# Altium schematic sheet uController_LCD.SchDoc
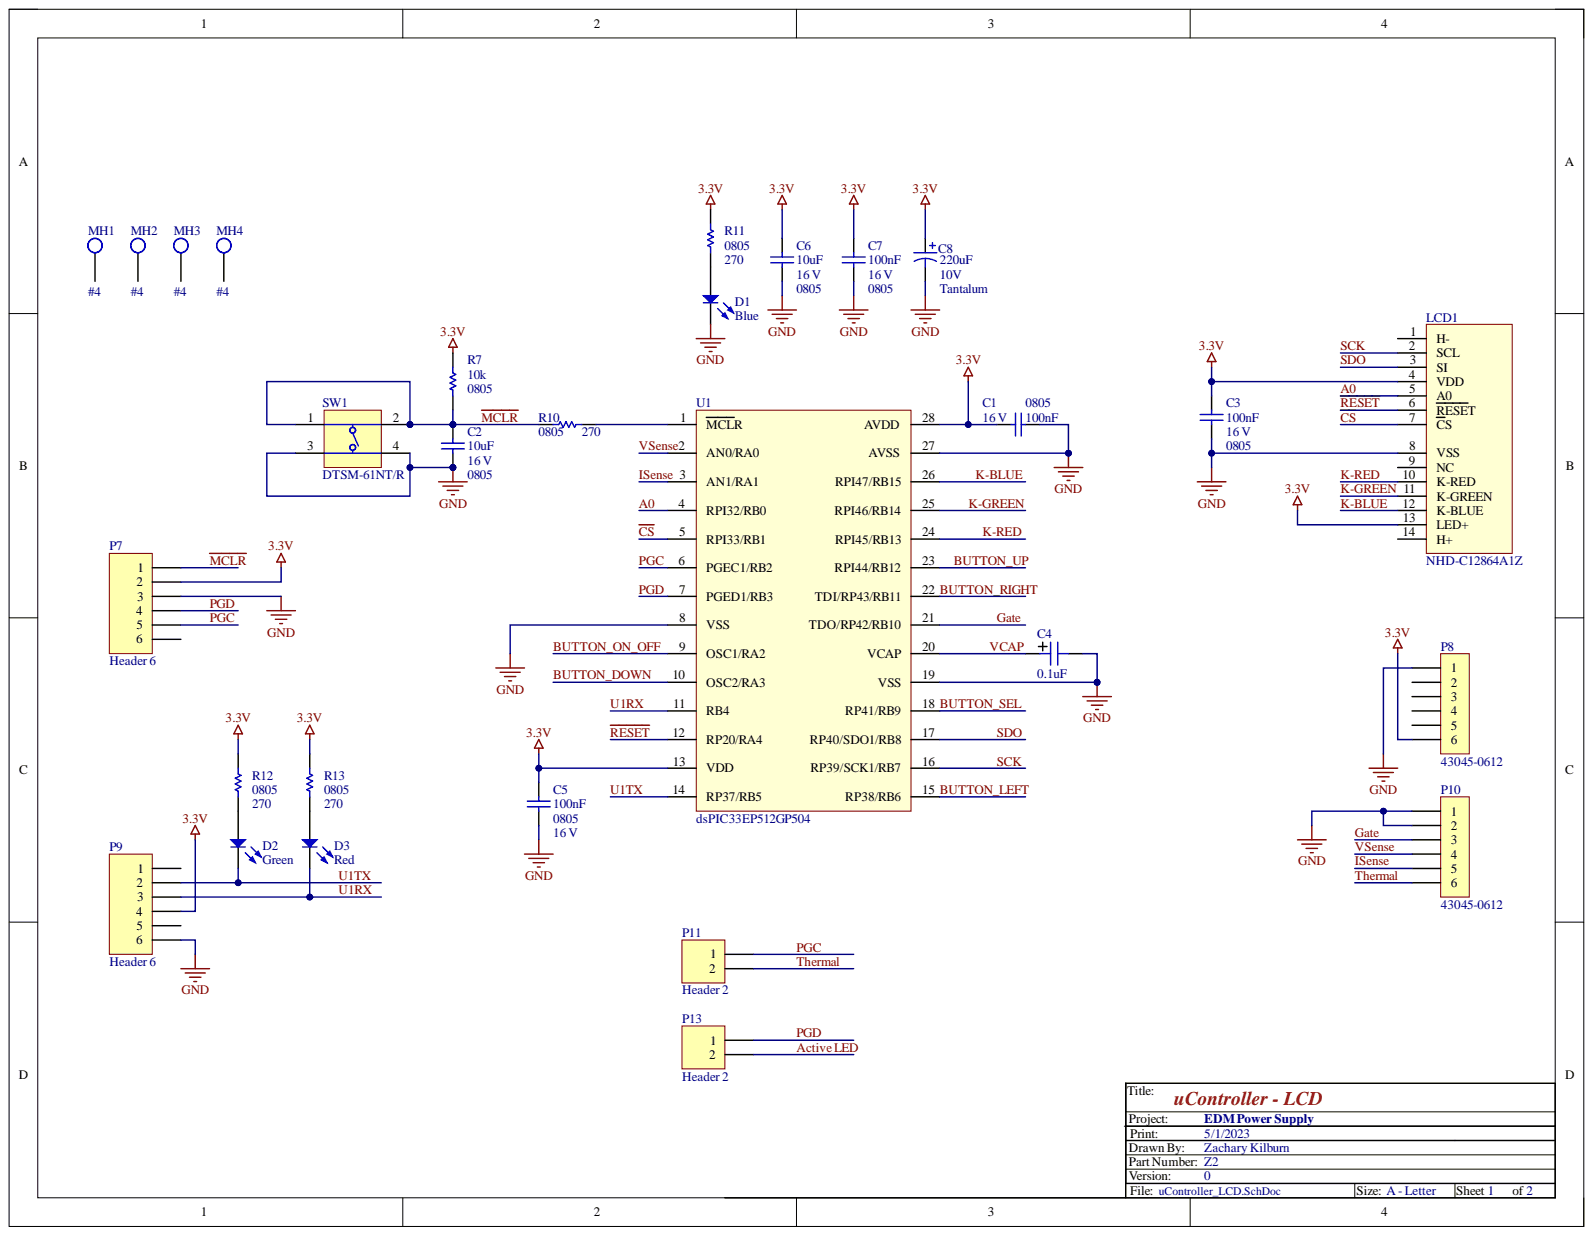
[diagram p0: uController_LCD.SchDoc]

## Parts
C1  value 100nF  Case-EIA: 0805  Rated Voltage: 16 V  [CAPC0805(2012)145_M]  (hidden comment: CAP 100nF 16V 0805(2012))
C2  value 10uF  Case-EIA: 0805  Rated Voltage: 16 V  [CAPC0805(2012)100_L]  (hidden comment: CAP 10uF 16V 0805(2012))
C3  value 100nF  Case-EIA: 0805  Rated Voltage: 16 V  [CAPC0805(2012)145_M]  (hidden comment: CAP 100nF 16V 0805(2012))
C4  0.1uF  [CAP POL 2917/7343]
C5  value 100nF  Case-EIA: 0805  Rated Voltage: 16 V  [CAPC0805(2012)145_M]  (hidden comment: CAP 100nF 16V 0805(2012))
C6  value 10uF  Case-EIA: 0805  Rated Voltage: 16 V  [CAPC0805(2012)100_L]  (hidden comment: CAP 10uF 16V 0805(2012))
C7  value 100nF  Case-EIA: 0805  Rated Voltage: 16 V  [CAPC0805(2012)145_M]  (hidden comment: CAP 100nF 16V 0805(2012))
C8  Capacitance: 220uF  Rated Voltage: 10V  Technology: Tantalum  [AVX-CAPC7343_V]  (hidden comment: TAJD227K010R)
D1  Blue  [0805_LED]
D2  Green  [0805_LED]
D3  Red  [0805_LED]
LCD1  NHD-C12864A1Z  [NHD-C12864A1Z-FS(RGB)-FBW-HT1]
MH1  #4  [PCC-XXX-MH-#4-ROUND-PLATED-H128P230M290]  (no BOM)
MH2  #4  [PCC-XXX-MH-#4-ROUND-PLATED-H128P230M290]  (no BOM)
MH3  #4  [PCC-XXX-MH-#4-ROUND-PLATED-H128P230M290]  (no BOM)
MH4  #4  [PCC-XXX-MH-#4-ROUND-PLATED-H128P230M290]  (no BOM)
P7  Header 6  [HDR1X6]
P8  43045-0612  [PCC-MOLX-43045-0612-R]
P9  Header 6  [HDR1X6]
P10  43045-0612  [PCC-MOLX-43045-0612-R]
P11  Header 2  [HDR1X2]
P13  Header 2  [HDR1X2]
R7  Package Reference: 0805  Resistance: 10k  [RESC2013X60X35ML10T20]  (hidden comment: RC0805JR-0710KL)
R10  value 270  Case-EIA: 0805  [RESC0805(2012)_N]  (hidden comment: 270R 5% 0805(2012))
R11  value 270  Case-EIA: 0805  [RESC0805(2012)_N]  (hidden comment: 270R 5% 0805(2012))
R12  value 270  Case-EIA: 0805  [RESC0805(2012)_N]  (hidden comment: 270R 5% 0805(2012))
R13  value 270  Case-EIA: 0805  [RESC0805(2012)_N]  (hidden comment: 270R 5% 0805(2012))
SW1  DTSM-61NT/R  [DIPT-DTSM-6_V]
U1  dsPIC33EP512GP504  [PDIP-28]

## Nets
3.3V: C1.1 C3.2 C5.2 C6.2 C7.2 C8.1 LCD1.4(VDD) LCD1.13(LED+) P7.2 P8.6 P9.4 R7.1 R11.1 R12.1 R13.1 U1.13(VDD) U1.28(AVDD)
GND: C1.2 C2.1 C3.1 C4.2 C5.1 C6.1 C7.1 C8.2 D1.2 LCD1.8(VSS) P7.3 P8.1 P9.6 P10.1 P10.2 SW1.3 SW1.4 U1.8(VSS) U1.19(VSS) U1.27(AVSS)
A0: LCD1.5(A0) U1.4(RPI32/RB0)
Active LED: P13.2
BUTTON_DOWN: U1.10(OSC2/RA3)
BUTTON_LEFT: U1.15(RP38/RB6)
BUTTON_ON_OFF: U1.9(OSC1/RA2)
BUTTON_RIGHT: U1.22(TDI/RP43/RB11)
BUTTON_SEL: U1.18(RP41/RB9)
BUTTON_UP: U1.23(RPI44/RB12)
~{CS}: LCD1.7(CS) U1.5(RPI33/RB1)
Gate: P10.3 U1.21(TDO/RP42/RB10)
ISense: P10.5 U1.3(AN1/RA1)
K-BLUE: LCD1.12(K-BLUE) U1.26(RPI47/RB15)
K-GREEN: LCD1.11(K-GREEN) U1.25(RPI46/RB14)
K-RED: LCD1.10(K-RED) U1.24(RPI45/RB13)
~{MCLR}: C2.2 P7.1 R7.2 R10.1 SW1.1 SW1.2
PGC: P7.5 P11.1 U1.6(PGEC1/RB2)
PGD: P7.4 P13.1 U1.7(PGED1/RB3)
~{RESET}: LCD1.6(RESET) U1.12(RP20/RA4)
SCK: LCD1.2(SCL) U1.16(RP39/SCK1/RB7)
SDO: LCD1.3(SI) U1.17(RP40/SDO1/RB8)
Thermal: P10.6 P11.2
U1RX: D3.2 P9.3 U1.11(RB4)
U1TX: D2.2 P9.2 U1.14(RP37/RB5)
VCAP: C4.1 U1.20(VCAP)
VSense: P10.4 U1.2(AN0/RA0)
NetD1_1: D1.1 R11.2
NetD2_1: D2.1 R12.2
NetD3_1: D3.1 R13.2
NetR10_2: R10.2 U1.1(MCLR)

Not connected: LCD1 1(H-) 9(NC) 14(H+); MH1 1; MH2 1; MH3 1; MH4 1; P7 6; P8 2 3 4 5; P9 1 5

## Library data of the parts
C1 C3 C5 C7 = CMP-1036-04404-1: CAP 100nF 16V ±20% 0805 (2012 Metric) Thickness 1.45mm SMD; Case-Metric: 2012; Tolerance: Â±20%; Component Kind: Standard; Library Name: Altium Content Vault; Max Thickness: 1.45 mm; Technology: SMT
C2 C6 = CMP-1036-04930-1: CAP 10uF 16V ±20% 0805 (2012 Metric) Thickness 1mm SMD; Case-Metric: 2012; Tolerance: Â±20%; Component Kind: Standard; Library Name: Altium Content Vault; Max Thickness: 1 mm; Technology: SMT
C4 = Capacitor: SMT Capacitor; Component Kind: Standard; Value: 0.1uF; Library Name: EDM.IntLib
C8 = CMP-0279-00002-2: Capacitor, Tantalum, 220uF 10% 10V MCCT-D (7343-31); Manufacturer: AVX; Polarity: Polarized; Component Kind: Standard; ComponentLink1Description: Manufacturer URL; ComponentLink1URL: http://www.avx.com/; ComponentLink2Description: Datasheet; ComponentLink2URL: http://www.avx.com/docs/Catalogs/taj.pdf; DatasheetVersion: Jul-13; Library Name: Altium Content Vault; Mounting Technology: Surface Mount; PackageDescription: 2-Pin SMT Capacitor, 7.3 x 4.3 mm Body; PackageReference: CAPC7343; PartNumber: TAJD227K010R; Tolerance: 10%
D1-D3 = LED: Component Kind: Standard; Description: LED; Revision: July-2002: Re-released for DXP Platform.; Library Name: EDM.IntLib
LCD1 = NHD-C12864A1Z-FS(RGB)-FBW-HT1: LCD Display, 3.3V, RGB Backlight, SPI; Component Kind: Standard; Library Name: EDM.IntLib
MH1-MH4 = CMP-000163-2: #4 Mounting Hole, Round, Plated, No Vias; Units: Imperial; Library Name: Mounting Hole Imperial.IntLib; Size: #4
P7 P9 = Header 6: Header, 6-Pin; Component Kind: Standard; LatestRevisionDate: 17-Jul-2002; LatestRevisionNote: Re-released for DXP Platform.; Library Name: Miscellaneous Connectors.IntLib; Signal Integrity: Connector; Publisher: Altium Limited
P8 P10 = CMP-000056-0: Molex Micro-Fit 3.0 Connector, Dual-Row, 6-Position, Vertical, 3mm Pitch; Current: 5A; MatingStyle: Plug; Positions: 6; Rows: 2; Voltage: 250V; Component Kind: Standard; ComponentLink1Description: Manufacturer URL; ComponentLink1URL: http://www.molex.com; ComponentLink2Description: Product URL; ComponentLink2URL: http://www.molex.com/molex/products/datasheet.jsp?part=active/0430450612_PCB_HEADERS.xml; ComponentLink3Description: Datasheet; ComponentLink3URL: http://www.molex.com/pdm_docs/ps/PS-43045.pdf; ComponentLink4Description: Package Specification; ComponentLink4URL: http://www.molex.com/pdm_docs/sd/430450612_sd.pdf; HelpURL: http://www.molex.com/pdm_docs/sd/430450612_sd.pdf; Library Name: Molex-Micro-Fit.IntLib; Manufacturer: Molex; MountingTechnology: Through Hole; OperatingTemperature: -40C to +105C; Orientation: Vertical; PackageName: Micro-Fit 3.0; PartNumber: 43045-0612; Pitch: 3mm; RoHS: Yes; StorageTemperature: -40C to +105C
P11 P13 = Header 2: Header, 2-Pin; Component Kind: Standard; LatestRevisionDate: 17-Jul-2002; LatestRevisionNote: Re-released for DXP Platform.; Library Name: Miscellaneous Connectors.IntLib; Signal Integrity: Connector; Publisher: Altium Limited
R7 = CMP-2000-05025-1: Chip Resistor, 10 KOhm, +/- 5%, 0.125 W, -55 to 155 degC, 0805 (2012 Metric), RoHS, Tape and Reel; Manufacturer: Yageo; Power Rating: 250mW; Product Range: RCSeries; Resistor Case Style: 0805[2012Metric]; Resistor Element Type: ThickFilm; SVHC: ToBeAdvised; Temperature Coefficient: ±100ppm/degC; Voltage Rating: 150V; Automotive Qualification Standard: -; Component Kind: Standard; Datasheet URL: http://www.yageo.ru/pdf/RC0805.pdf; Datasheet Version: REV. 2, 09/2004; Library Name: Altium Content Vault; Manufacturer URL: http://www.yageo.com/; MSL: MSL1-Unlimited; Package Description: 2-Pin Surface Mounted Device, Body 2 x 1.25 mm; Packaging: CutTape; Resistance Tolerance: ±5%
R10 = CMP-1013-00060-1: 270R 0.125W 5% 0805 (2012 Metric)  SMD; Case-Metric: 2012; Component Kind: Standard; Library Name: Altium Content Vault; Power: 0.125 W; Technology: SMT; Tolerance: 5 %
R11-R13 = CMP-1013-00060-1: 270R 0.125W 5% 0805 (2012 Metric)  SMD; Case-Metric: 2012; Value: 270; Component Kind: Standard; Library Name: Altium Content Vault; Power: 0.125 W; Technology: SMT; Tolerance: 5 %
SW1 = CMP-0306-00001-1: Switch, SPNO Push Button SMD; Manufacturer: Diptronics; Mechanical Life: 200,000 cycles; Packing Type: Tape&Reel; Component Kind: Standard; ComponentLink1Description: Manufacturer URL; ComponentLink1URL: http://www.dip.com.tw/; ComponentLink2Description: Datasheet; ComponentLink2URL: http://www.dip.com.tw/Products_tact.htm; ComponentLink3Description: Package Specification; ComponentLink3URL: http://www.dip.com.tw/PDF/pro_tact/DTSM_G_-6_2_-V.pdf; Library Name: Altium Content Vault; Mounting Technology: Through Hole; PackageDescription: Switch, Through-Hole, 4 Leads, Body 8.5x8.5mm, Row Spacing 9.0mm, Pitch 4.5mm; PackageReference: DTSM-6; PartNumber: DTSM-61NT/R
U1 = dsPIC33EP512GP504: MCU, 16-bit, DIP-28; Component Kind: Standard; Library Name: EDMLib.SchLib
